# Altium schematic sheet Ersatz_LP.SchDoc
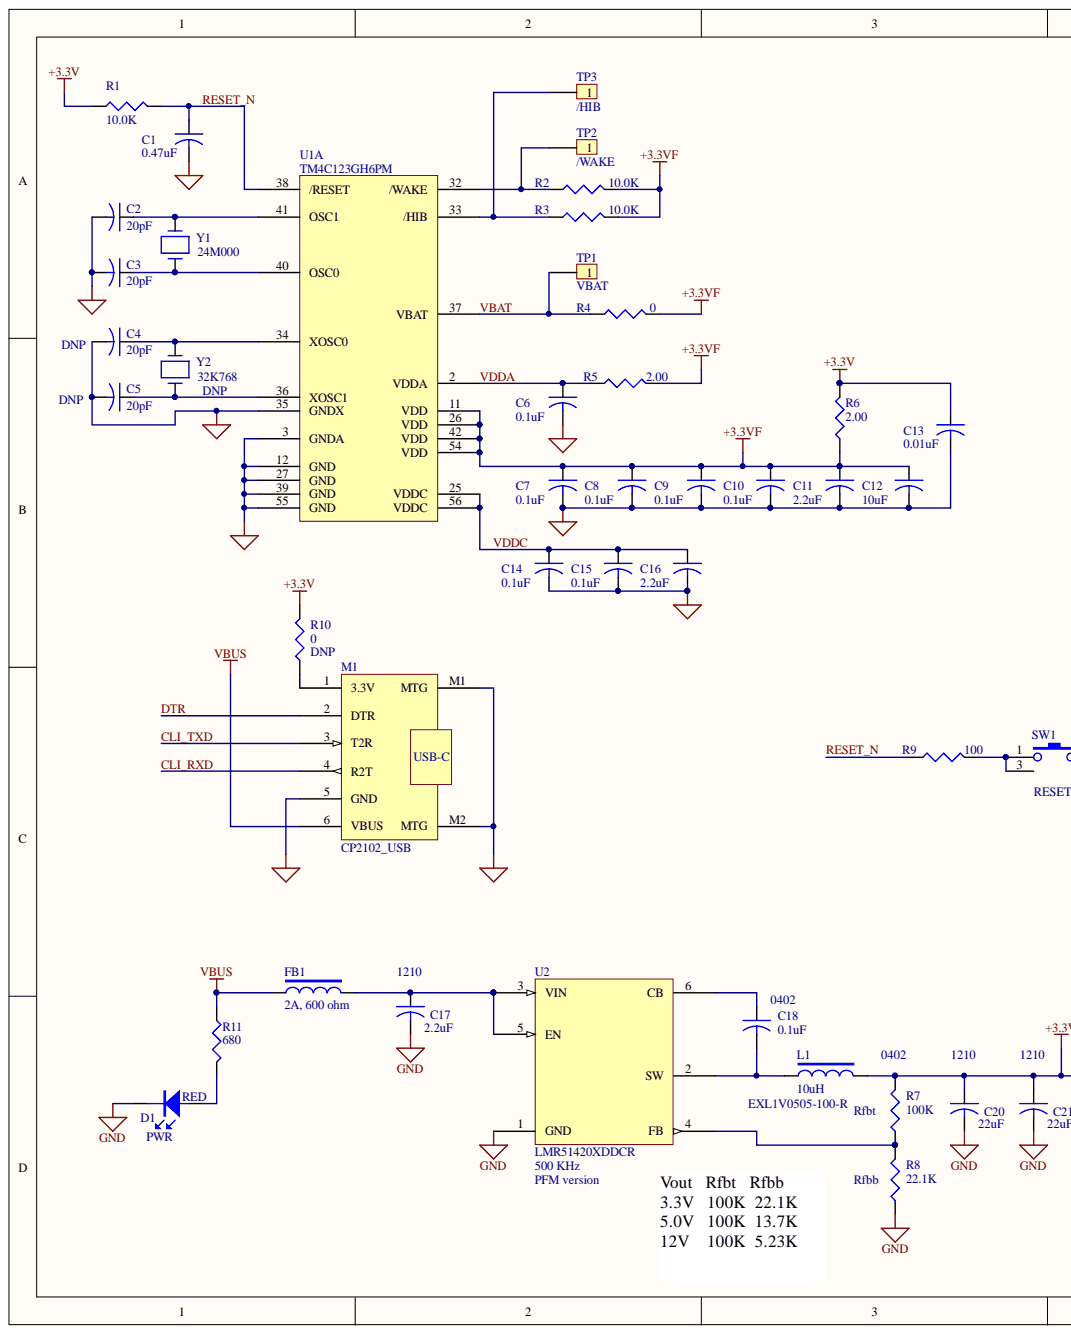
[diagram p0: Ersatz_LP.SchDoc, left]
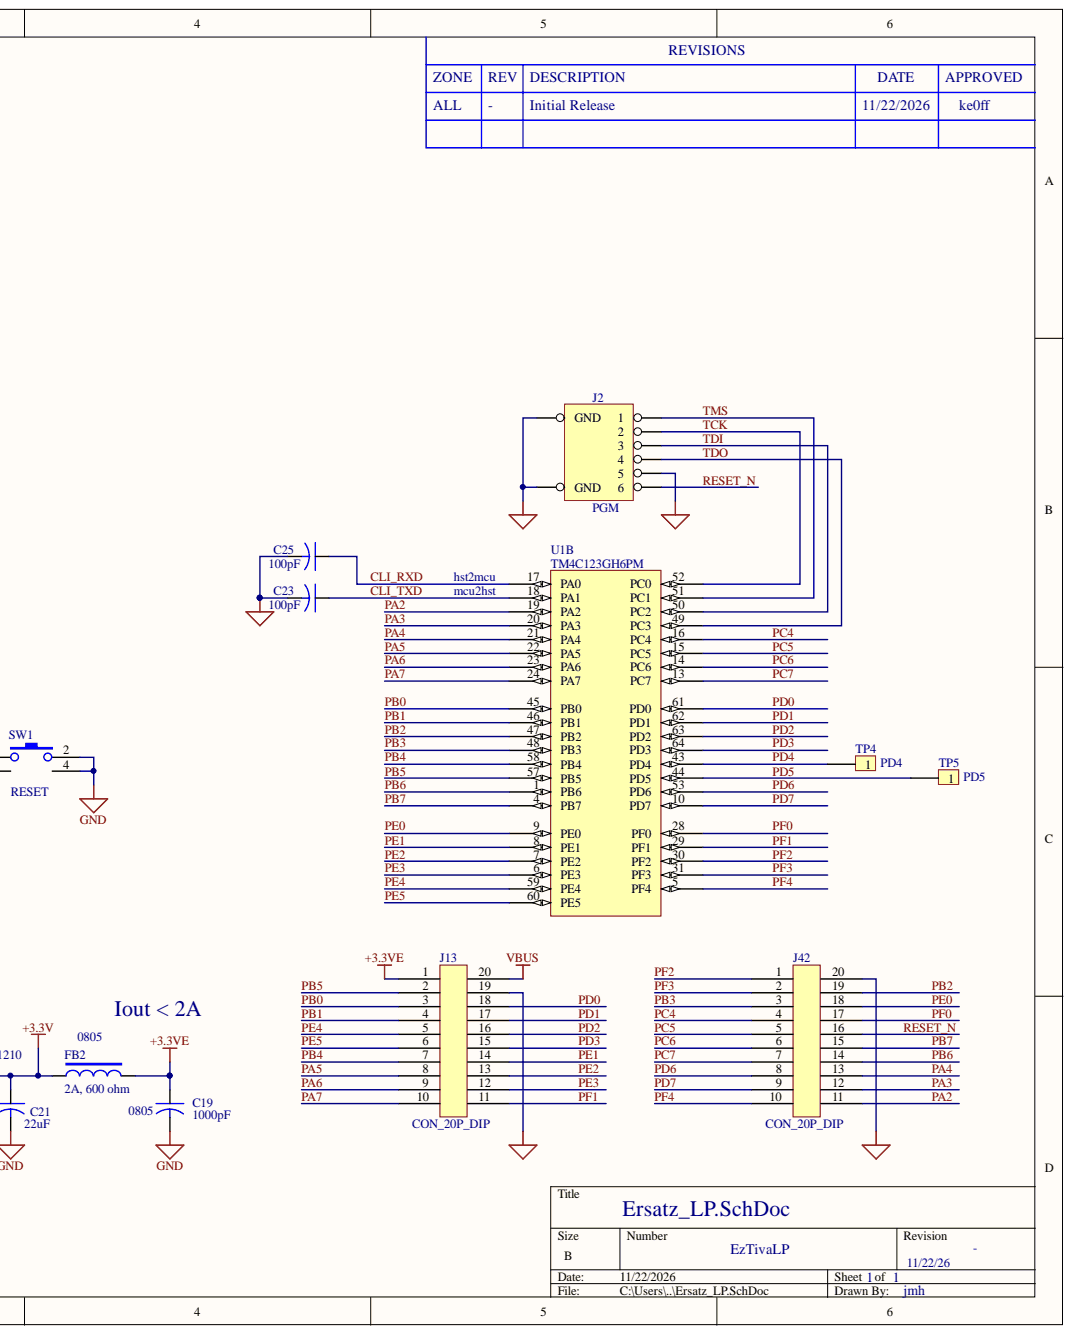
[diagram p1: Ersatz_LP.SchDoc, right]

## Parts
C1  0.47uF  [0805]
C2  20pF  [0402]
C3  20pF  [0402]
C4  20pF  [0402]
C5  20pF  [0402]
C6  0.1uF  [0402]
C7  0.1uF  [0402]
C8  0.1uF  [0402]
C9  0.1uF  [0402]
C10  0.1uF  [0402]
C11  2.2uF  [0603]
C12  10uF  [0805]
C13  0.01uF  [0402]
C14  0.1uF  [0402]
C15  0.1uF  [0402]
C16  2.2uF  [0603]
C17  2.2uF  [1210]
C18  0.1uF  [0402]
C19  1000pF  [0805]
C20  22uF  [1210]
C21  22uF  [1210]
C23  100pF  [0402]
C25  100pF  [0402]
D1  PWR  NOTES: RED  [0805-LED]
FB1  2A, 600 ohm  [0805]
FB2  2A, 600 ohm  [0805]
J2  PGM  [CON_1.25MM_6P]
J13  CON_20P_DIP  [CON_TIVA_2X10]  (value not drawn)
J42  CON_20P_DIP  [CON_TIVA_2X10]  (value not drawn)
L1  10uH  MPN: EXL1V0505-100-R  [IND_EXL1V0505]
M1  CP2102_USB  [CP2102_USBCOM]
R1  10.0K  [0402]
R2  10.0K  [0402]
R3  10.0K  [0402]
R4  0  [0402]
R5  2.00  [0402]
R6  2.00  [0402]
R7  100K  [0402]
R8  22.1K  [0402]
R9  100  [0402]
R10  0  [0402]
R11  680  [0402]
SW1  RESET  [SW_PB_V]
TP1  VBAT  [TP-SMD-40X80-SQ]  (no BOM)
TP2  /WAKE  [TP-SMD-40X80-SQ]  (no BOM)
TP3  /HIB  [TP-SMD-40X80-SQ]  (no BOM)
TP4  PD4  [TP-SMD-40X80-SQ]  (no BOM)
TP5  PD5  [TP-SMD-40X80-SQ]  (no BOM)
U1  TM4C123GH6PM  [TQFP-64]  (parts A,B)
U2  LMR51420XDDCR  [SOT23-6]
Y1  24M000  [XTAL_SMD]
Y2  32K768  [XTAL_AB26TRB]

## Nets
3: Y2.3
+3.3V: C13.1 C20.1 C21.1 FB2.1 L1.2 R1.1 R6.2 R7.2 R10.2
+3.3VE: C19.1 FB2.2 J13.1
+3.3VF: C7.1 C8.1 C9.1 C10.1 C11.1 C12.1 R2.2 R3.2 R4.2 R5.2 R6.1 U1.11(VDD) U1.26(VDD) U1.42(VDD) U1.54(VDD)
GND: C1.2 C2.2 C3.2 C4.2 C5.2 C6.2 C7.2 C8.2 C9.2 C10.2 C11.2 C12.2 C13.2 C14.2 C15.2 C16.2 C17.2 C19.2 C20.2 C21.2 C23.2 C25.2 D1.1 J2.5 J2.7(GND) J2.8(GND) J13.19 J42.20 M1.5(GND) M1.M1(MTG) M1.M2(MTG) R8.1 SW1.2 SW1.4 U1.3(GNDA) U1.12(GND) U1.27(GND) U1.35(GNDX) U1.39(GND) U1.55(GND) U2.1(GND)
VBUS: FB1.1 J13.20 M1.6(VBUS) R11.1
CLI_RXD: C25.1 M1.4(R2T) U1.17(PA0)
CLI_TXD: C23.1 M1.3(T2R) U1.18(PA1)
DTR: M1.2(DTR)
PA2: J42.11 U1.19(PA2)
PA3: J42.12 U1.20(PA3)
PA4: J42.13 U1.21(PA4)
PA5: J13.8 U1.22(PA5)
PA6: J13.9 U1.23(PA6)
PA7: J13.10 U1.24(PA7)
PB0: J13.3 U1.45(PB0)
PB1: J13.4 U1.46(PB1)
PB2: J42.19 U1.47(PB2)
PB3: J42.3 U1.48(PB3)
PB4: J13.7 U1.58(PB4)
PB5: J13.2 U1.57(PB5)
PB6: J42.14 U1.1(PB6)
PB7: J42.15 U1.4(PB7)
PC4: J42.4 U1.16(PC4)
PC5: J42.5 U1.15(PC5)
PC6: J42.6 U1.14(PC6)
PC7: J42.7 U1.13(PC7)
PD0: J13.18 U1.61(PD0)
PD1: J13.17 U1.62(PD1)
PD2: J13.16 U1.63(PD2)
PD3: J13.15 U1.64(PD3)
PD4: TP4.1 U1.43(PD4)
PD5: TP5.1 U1.44(PD5)
PD6: J42.8 U1.53(PD6)
PD7: J42.9 U1.10(PD7)
PE0: J42.18 U1.9(PE0)
PE1: J13.14 U1.8(PE1)
PE2: J13.13 U1.7(PE2)
PE3: J13.12 U1.6(PE3)
PE4: J13.5 U1.59(PE4)
PE5: J13.6 U1.60(PE5)
PF0: J42.17 U1.28(PF0)
PF1: J13.11 U1.29(PF1)
PF2: J42.1 U1.30(PF2)
PF3: J42.2 U1.31(PF3)
PF4: J42.10 U1.5(PF4)
RESET_N: C1.1 J2.6 J42.16 R1.2 R9.1 U1.38(/RESET)
TCK: J2.2 U1.52(PC0)
TDI: J2.3 U1.50(PC2)
TDO: J2.4 U1.49(PC3)
TMS: J2.1 U1.51(PC1)
VBAT: R4.1 TP1.1 U1.37(VBAT)
VDDA: C6.1 R5.1 U1.2(VDDA)
VDDC: C14.1 C15.1 C16.1 U1.25(VDDC) U1.56(VDDC)
NetC2_1: C2.1 U1.41(OSC1) Y1.1
NetC3_1: C3.1 U1.40(OSC0) Y1.2
NetC4_1: C4.1 U1.34(XOSC0) Y2.2
NetC5_1: C5.1 U1.36(XOSC1) Y2.1
NetC17_1: C17.1 FB1.2 U2.3(VIN) U2.5(EN)
NetC18_1: C18.1 U2.6(CB)
NetC18_2: C18.2 L1.1 U2.2(SW)
NetD1_2: D1.2 R11.2
NetM1_1: M1.1(3.3V) R10.1
NetR2_1: R2.1 TP2.1 U1.32(/WAKE)
NetR3_1: R3.1 TP3.1 U1.33(/HIB)
NetR7_1: R7.1 R8.2 U2.4(FB)
NetR9_2: R9.2 SW1.1 SW1.3

## Library data of the parts
C1 = CAP 0805: CAP, MLCC, X7R, 50V, 0805, 0.47uF; MFR: Yageo; MFR_ALT: AVX; MPN: CC0805KX7R0BB474; MPN_ALT: 08055C474KAZ2A; Component Kind: Standard; VOLTAGE: 50V
C2-C5 = CAP 0805: CAP, MLCC, C0G, 50V, 0402, 18pF; MFR: Kemet; MPN: C0402C180J5GAC; Component Kind: Standard; VOLTAGE: 50V
  C4 C5: DNP: DNP
C6-C10 C14 C15 C18 = CAP 0805: CAP, MLCC, X7R, 50V, 0402, 0.1uF; MFR: Murata; MPN: GRM155R71H104KE14J; Component Kind: Standard; VOLTAGE: 50V
C11 C16 = CAP 0805: CAP, MLCC, X7R, 50V, 0603, 2.2uF; MFR: Taiyo Yuden; MPN: UMK107BBJ225KA-T; Component Kind: Standard; VOLTAGE: 50V
C12 = CAP 0805: CAP, MLCC, X7R, 25V, 0805, 10uF; MFR: Samsung; MPN: CL21B106KAYQNNE; Component Kind: Standard; VOLTAGE: 25V
C13 = CAP 0805: CAP, MLCC, X7R, 50V, 0402, 0.01uF; MFR: Murata; MPN: GRM1555CYA103GE01D; Component Kind: Standard; VOLTAGE: 50V
C17 = CAP 0805: CAP, MLCC, X7R, 1206, 100V, 10%, 2.2uF; MFR: AVX; MPN: 12061C225K4T2A; VOLTAGE: 100; Component Kind: Standard
C19 = CAP 0805: CAP, MLCC, C0G, 0805, 100V, 10%, 1000pF; MFR: Kemet; MPN: C0402C102J1GEC7411; VOLTAGE: 100; Component Kind: Standard
C20 C21 = CAP 0805: CAP, MLCC, X7R, 1210, 25V, 10%, 22uF; MFR: Samsung; MPN: CL32B226KAJNNNE; Component Kind: Standard; VOLTAGE: 25V
C23 C25 = CAP 0805: CAP, MLCC, C0G, 50V, 0402, 100pF; MFR: TDK; MPN: C1005C0G2A101J050BE; Component Kind: Standard; VOLTAGE: 50V
D1 = LED: LED, SMD; ALT-MPN: -; Component Kind: Standard; DNP: -; MFR: -; MPN: -; NOTES2: -; PRI-MPN: -; Library Name: Galaxy SCH-jem-1.08.SchLib
FB1 FB2 = FB-100: Bead, Ferrite, SMD, 80 ohm; Component Kind: Standard; MFR: ?; MPN: ?; Library Name: RFCCA.SchLib
J2 = HEADER-6-PIN-RT-ANG: 6-PIN JTAG HDR; ALT-MPN: ‎53261-0671 (R/A)‎; MFR: Molex; MPN: 53398-0671; Component Kind: Standard
J13 J42 = CON_20P_DIP: CON, DIP, 20 POS, 0.1" LS; ALT-MPN: -; Component Kind: Standard; DNP: -; MFR: -; MPN: -; NOTES: -; NOTES2: -; PRI-MPN: -; Library Name: Galaxy SCH-jem-1.08.SchLib
L1 = INDUCTOR2: IND, SMD, 10uH, 5.4A; MFR: Coiltronics; ALT-MPN: -; Component Kind: Standard; DNP: -; NOTES: -; NOTES2: -; PRI-MPN: -; Library Name: Galaxy SCH-jem-1.08.SchLib
M1 = CP2102_MDL: MDL, USB-COM, CP2102, 21x16mm; MFR: Amazon; MPN: 3Pcs CP2102 USB to UART Serial Adapter for Arduino ESP32 Raspberry Pi Type-C USB TTL Module; ALT-MPN: -; Component Kind: Standard; DNP: -; NOTES: -; NOTES2: -; PRI-MPN: -; Library Name: Galaxy SCH-jem-1.08.SchLib
R1-R3 = R0805: RES, CHP, 1%, 0402, 10K; MFR: Yageo; MPN: RC0402FR-0710KL; Component Kind: Standard
R4 = R0805: RES, CHP, 1%, 0402, 0; MFR: Yageo; MPN: RC0402FR-070RL; Component Kind: Standard
R5 R6 = R0805: RES, CHP, 1%, 0402, 2.00; MFR: Yageo; MPN: RC0402FR-072RL; Component Kind: Standard
R7 = Res3: RES, CHP, 0402, 1%, 100ppm/C, 100K; MFR: Yageo; MPN: RC0402FR-07100KP; Component Kind: Standard; LatestRevisionDate: 29-May-2009; LatestRevisionNote: IPC-7351 Footprint Added.; Library Name: Miscellaneous Devices.IntLib; PackageDescription: Chip Resistor; PackageReference: J1-0402; Published: 8-Jun-2000; Simulation: RESISTOR; Value: 100K; Publisher: Altium Limited
R8 = Res3: RES, CHP, 0402, 1%, 100ppm/C, 22.1K; MFR: Yageo; MPN: RC0402FR-0722K1P; Component Kind: Standard; LatestRevisionDate: 29-May-2009; LatestRevisionNote: IPC-7351 Footprint Added.; Library Name: Miscellaneous Devices.IntLib; PackageDescription: Chip Resistor; PackageReference: J1-0402; Published: 8-Jun-2000; Simulation: RESISTOR; Value: 22.1K; Publisher: Altium Limited
R9 = R0805: RES, CHP, 1%, 0402, 100; MFR: Yageo; MPN: RC0402FR-07100RL; Component Kind: Standard
R10 = Res3: RES, CHP, 1%, 0402, 0; MFR: Yageo; MPN: RC0402FR-070RL; Component Kind: Standard; DNP: DNP; LatestRevisionDate: 29-May-2009; LatestRevisionNote: IPC-7351 Footprint Added.; Library Name: Miscellaneous Devices.IntLib; PackageDescription: Chip Resistor; PackageReference: J1-0402; Published: 8-Jun-2000; Simulation: RESISTOR; Value: 0; Publisher: Altium Limited
R11 = R0805: RES, CHP, 0402, 1%, 680; MFR: Yageo; MPN: RC0402FR-07680RL; ALT-MPN: -; Component Kind: Standard; DNP: -; NOTES: -; NOTES2: -; PRI-MPN: -; Library Name: Galaxy SCH-jem-1.08.SchLib
SW1 = SW-PB-4: SW, PB, NO, 4-PIN; ALT-MPN: -; Component Kind: Standard; DNP: -; MFR: -; MPN: -; NOTES: -; NOTES2: -; PRI-MPN: -; Library Name: Galaxy SCH-jem-1.08.SchLib
TP1-TP5 = TP: Library Name: Galaxy SCH-jem-1.08.lib
U1 = TM4C123GH6PM: IC, MCU, ARM, CORTEX, TI; MPN: TM4C123GH6PMT; Component Kind: Standard; MFR: TI; Library Name: MorseHID_revA.SCHLIB
U2 = LMR51420: IC, REG, SW, BUCK; MFR: TI; ALT-MPN: -; Component Kind: Standard; DNP: -; MPN: LMR51420XDDCR; NOTES: -; NOTES2: -; PRI-MPN: -; Library Name: Galaxy SCH-jem-1.08.SchLib
Y1 = CRYSTAL-2PIN: CRYSTAL 2 PIN; MFR: Abracon; MPN: ABM3-24.000MHZ-B2-T; Component Kind: Standard
Y2 = CRYSTAL-3PIN: CRYSTAL 3 PIN; MFR: any; MPN: 32KHz; Component Kind: Standard; DNP: DNP
